# Altium schematic sheet p13_IMU.SchDoc
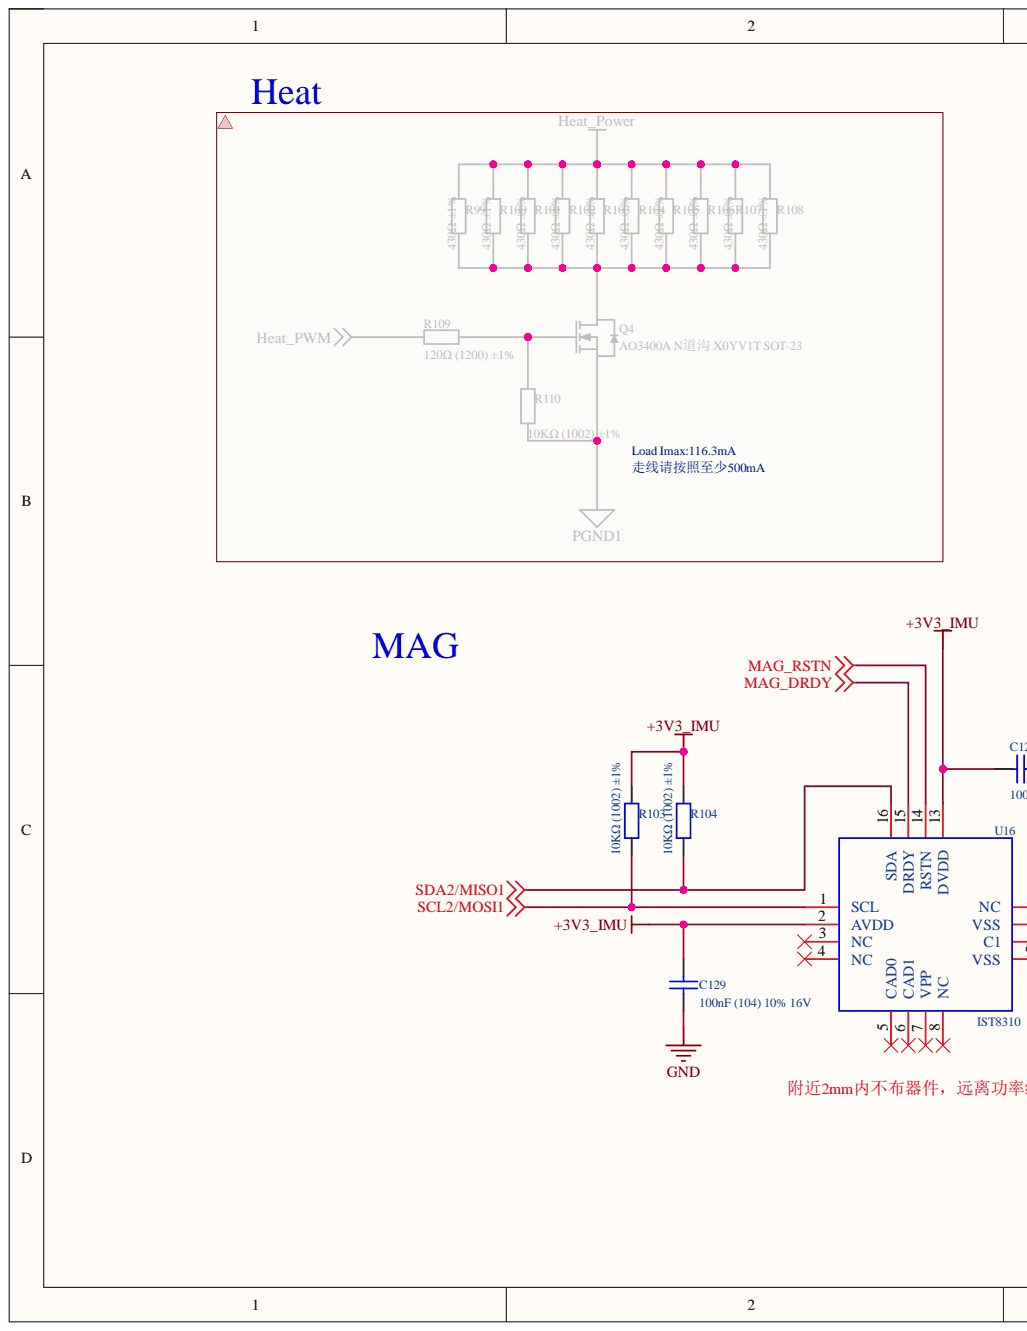
[diagram p0: p13_IMU.SchDoc, left]
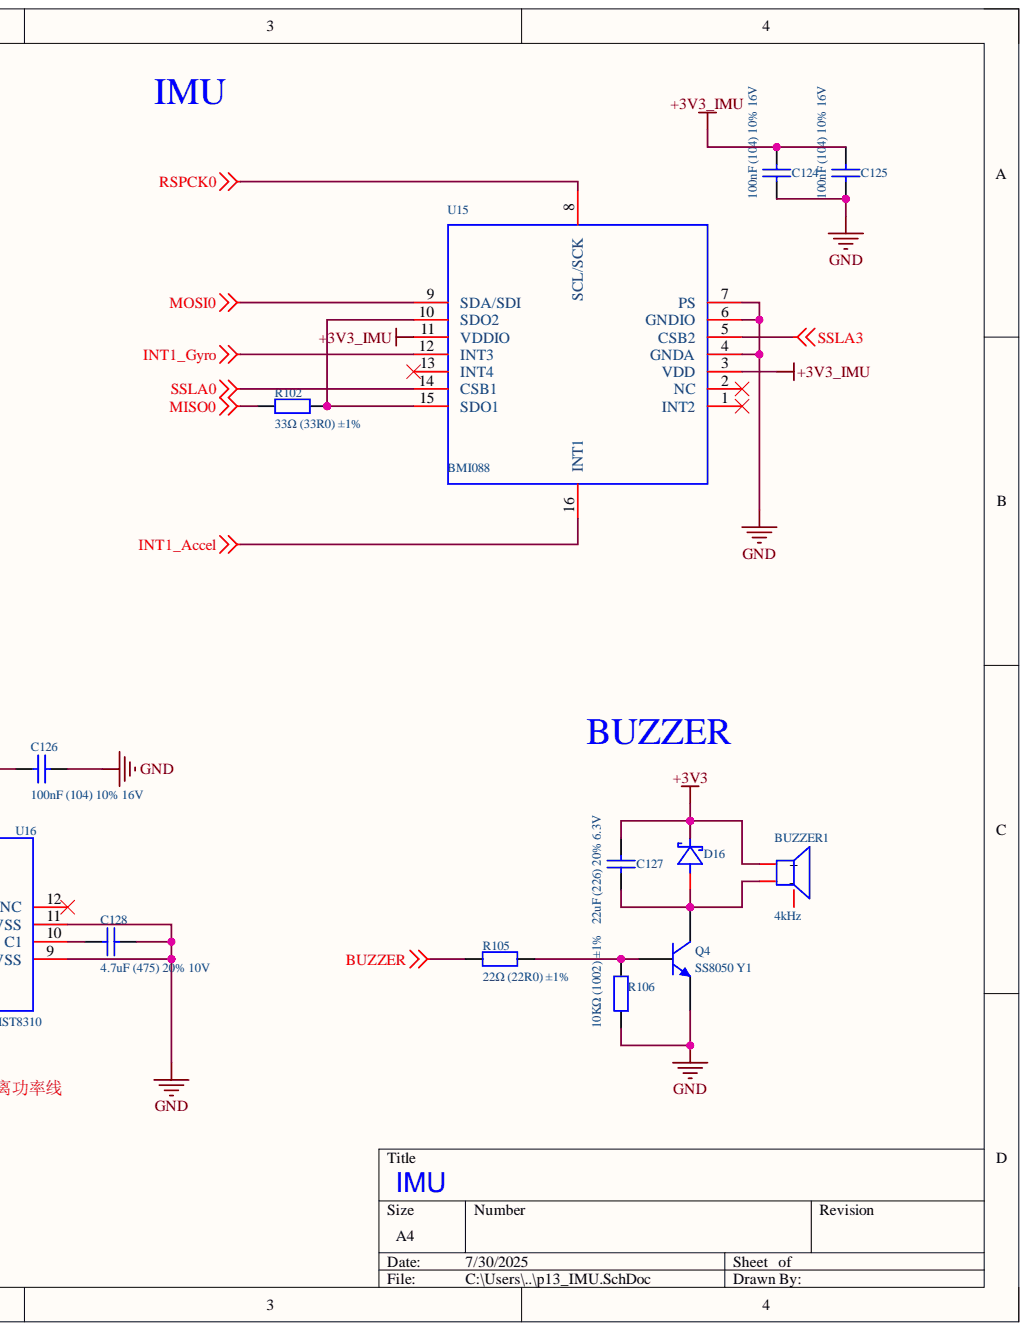
[diagram p1: p13_IMU.SchDoc, right]

## Parts
BUZZER1  4kHz  [BUZ-SMD_L5.0-W5.5-P4.60]
C124  100nF (104) 10% 16V  [C0201]
C125  100nF (104) 10% 16V  [C0201]
C126  100nF (104) 10% 16V  [C0201]
C127  22uF (226) 20% 6.3V  [C0603]
C128  4.7uF (475) 20% 10V  [C0402]
C129  100nF (104) 10% 16V  [C0201]
D16  SD103AWS  [SOD-323_L1.7-W1.3-LS2.5-RD-1]  (value not drawn)
Q4  SS8050 Y1  [SOT-23(SOT-23-3)]
R102  33Ω (33R0) ±1%  [R0402]
R103  10KΩ (1002) ±1%  [R0402]
R104  10KΩ (1002) ±1%  [R0402]
R105  22Ω (22R0) ±1%  [R0402]
R106  10KΩ (1002) ±1%  [R0402]
U15  BMI088  [LGA-16_L4.5-W3.0-P0.50-BL]
U16  IST8310  [LGA-16_L3.0-W3.0-P0.50-BL_SQ]

## Nets
+3V3: BUZZER1.1 C127.2 D16.1
+3V3_IMU: C124.2 C125.2 C126.1 C129.2 R103.2 R104.2 U15.3(VDD) U15.11(VDDIO) U16.2(AVDD) U16.13(DVDD)
BUZZER: R105.1
GND: C124.1 C125.1 C126.2 C128.1 C129.1 Q4.2 R106.1 U15.4(GNDA) U15.6(GNDIO) U15.7(PS) U16.9(VSS) U16.11(VSS)
INT1_Accel: U15.16(INT1)
INT1_Gyro: U15.12(INT3)
MAG_DRDY: U16.15(DRDY)
MAG_RSTN: U16.14(RSTN)
MISO0: R102.1
MOSI0: U15.9(SDA/SDI)
RSPCK0: U15.8(SCL/SCK)
SCL2/MOSI1: R103.1 U16.1(SCL)
SDA2/MISO1: R104.1 U16.16(SDA)
SSLA0: U15.14(CSB1)
SSLA3: U15.5(CSB2)
NetBUZZER1_2: BUZZER1.2 C127.1 D16.2 Q4.3
NetC128_2: C128.2 U16.10(C1)
NetQ4_1: Q4.1 R105.2 R106.2
NetR102_2: R102.2 U15.10(SDO2) U15.15(SDO1)

Not connected: BUZZER1 3; U15 1(INT2) 2(NC) 13(INT4); U16 3(NC) 4(NC) 5(CAD0) 6(CAD1) 7(VPP) 8(NC) 12(NC)

## Library data of the parts
BUZZER1 = MLT-5020: Manufacturer: 华能; Add into BOM: yes; Driver Circuitry: 无源(外部驱动); Component Kind: Standard; Convert to PCB: yes; Datasheet: https://atta.szlcsc.com/upload/public/pdf/source/20210811/111454390007D221D7259018FB170E62.pdf; Device: MLT-5020; Height: 2.5mm; JLCPCB Part Class: Extended Part; LCSC Part Name: MLT-5020; Length: 5.2mm; Library Name: ra8p1_v0.1.SCHLIB; Manufacturer Part: MLT-5020; PCB3D: BUZ-SMD_3P-L5.2-W5.2-H2.0-P3.50; Supplier: LCSC; Supplier Footprint: SMD,5x5mm; Supplier Part: C94598; Symbol: MLT-5020; Technology: 电磁式; Value: 4kHz; Width: 5.2mm
C124-C126 C129 = 0402 100nF (104) 10% 16V: 贴片电容; Component Kind: Standard; ComponentLink1Description: 供应商链接; ComponentLink1URL: https://item.szlcsc.com/42839.html; Library Name: Cap.SchLib; Package: 0402; Supplier: LC; SuppliersPartNumber: C1525
C127 = 0603 22uF (226) 20% 6.3V: 贴片电容; Component Kind: Standard; ComponentLink1Description: 供应商链接; ComponentLink1URL: http://www.szlcsc.com/product/details_60514.html; Library Name: Cap.SchLib; Package: 0603; Supplier: LC; SuppliersPartNumber: C59461
C128 = 0402 4.7uF (475) 20% 10V: 贴片电容; Component Kind: Standard; ComponentLink1Description: 供应商链接; ComponentLink1URL: http://www.szlcsc.com/product/details_24469.html; Library Name: Cap.SchLib; Package: 0402; Supplier: LC; SuppliersPartNumber: C23733
D16 = SD103AWS_C7420360: Manufacturer: 宏迦橙; Add into BOM: yes; Average Rectified Current (Io): 350mA; Diode Configuration: 独立式; Forward Voltage (Vf@If): 370mV@20mA; Peak forward surge current: 1.5A; Reverse Leakage Current (Ir): 5uA@40V; Reverse Voltage (Vr): 40V; Component Kind: Standard; Convert to PCB: yes; Datasheet: https://atta.szlcsc.com/upload/public/pdf/source/20230828/EB98D42952FEC013AACB2F62A2475546.pdf; Device: SD103AWS_C7420360; JLCPCB Part Class: Extended Part; LCSC Part Name: 电压:40V 电流:350mA; Library Name: ra8p1_v0.1.SCHLIB; Manufacturer Part: SD103AWS; PCB3D: SOD-323_L1.7-W1.3-LS2.6-RD-1; Supplier: LCSC; Supplier Footprint: SOD-323; Supplier Part: C7420360; Symbol: SD103AWS_C7420360
Q4 = SOT-23 SS8050 Y1: 三极管; Component Kind: Standard; ComponentLink1Description: 供应商链接; ComponentLink1URL: http://www.szlcsc.com/product/details_2507.html; Library Name: Transistor_NPN.SchLib; Package: SOT-23; Supplier: LC; SuppliersPartNumber: C2150
R102 = 0402 33Ω (33R0) 1%: 贴片电阻; Component Kind: Standard; ComponentLink1Description: 供应商链接; ComponentLink1URL: http://www.szlcsc.com/product/details_25848.html; Library Name: RES.SchLib; Package: 0402; Supplier: LC; SuppliersPartNumber: C25105
R103 R104 R106 = 0402 10KΩ (1002) 1%: 贴片电阻，10K; Component Kind: Standard; ComponentLink1Description: 供应商链接; ComponentLink1URL: http://www.szlcsc.com/product/details_26487.html; Library Name: RES.SchLib; Package: 0402; Supplier: LC; SuppliersPartNumber: C25744
R105 = 0402 22Ω (22R0) 1%: 贴片电阻; Component Kind: Standard; ComponentLink1Description: 供应商链接; ComponentLink1URL: http://www.szlcsc.com/product/details_25835.html; Library Name: RES.SchLib; Package: 0402; Supplier: LC; SuppliersPartNumber: C25092
U15 = BMI088: 6axis IMU; Manufacturer: Bosch(博世); Add into BOM: yes; Sensor Type: 加速度计陀螺仪; Component Kind: Standard; Convert to PCB: yes; Datasheet: https://atta.szlcsc.com/upload/public/pdf/source/20180628/C194919_66CCF125FF968C218A4D358C68AC68D6.pdf; Device: BMI088; JLCPCB Part Class: Extended Part; LCSC Part Name: BMI088; Library Name: ra8p1_v0.1.SCHLIB; Manufacturer Part: BMI088; Operating Temperature: -40℃~+85℃; PCB3D: LGA-16_L4.5-W3.0-H1.0-P0.50-BL; Supplier: LCSC; Supplier Footprint: LGA-16(3x4.5); Supplier Part: C194919; Symbol: BMI088
U16 = IST8310_C2683055: 6axis MAG; Manufacturer: iSentek(爱盛科技); Add into BOM: yes; Component Kind: Standard; Convert to PCB: yes; Datasheet: https://atta.szlcsc.com/upload/public/pdf/source/20250224/70FB1E25A3B1BEF4BDD1315496E152E4.pdf; Device: IST8310_C2683055; JLCPCB Part Class: Extended Part; LCSC Part Name: IST8310; Library Name: ra8p1_v0.1.SCHLIB; Manufacturer Part: IST8310; PCB3D: LGA-16_L3.0-W3.0-H0.9-P0.50; Supplier: LCSC; Supplier Footprint: LGA-16(3x3); Supplier Part: C2683055; Symbol: IST8310_C2683055
